# Altium schematic sheet Controller.SchDoc(Controller)
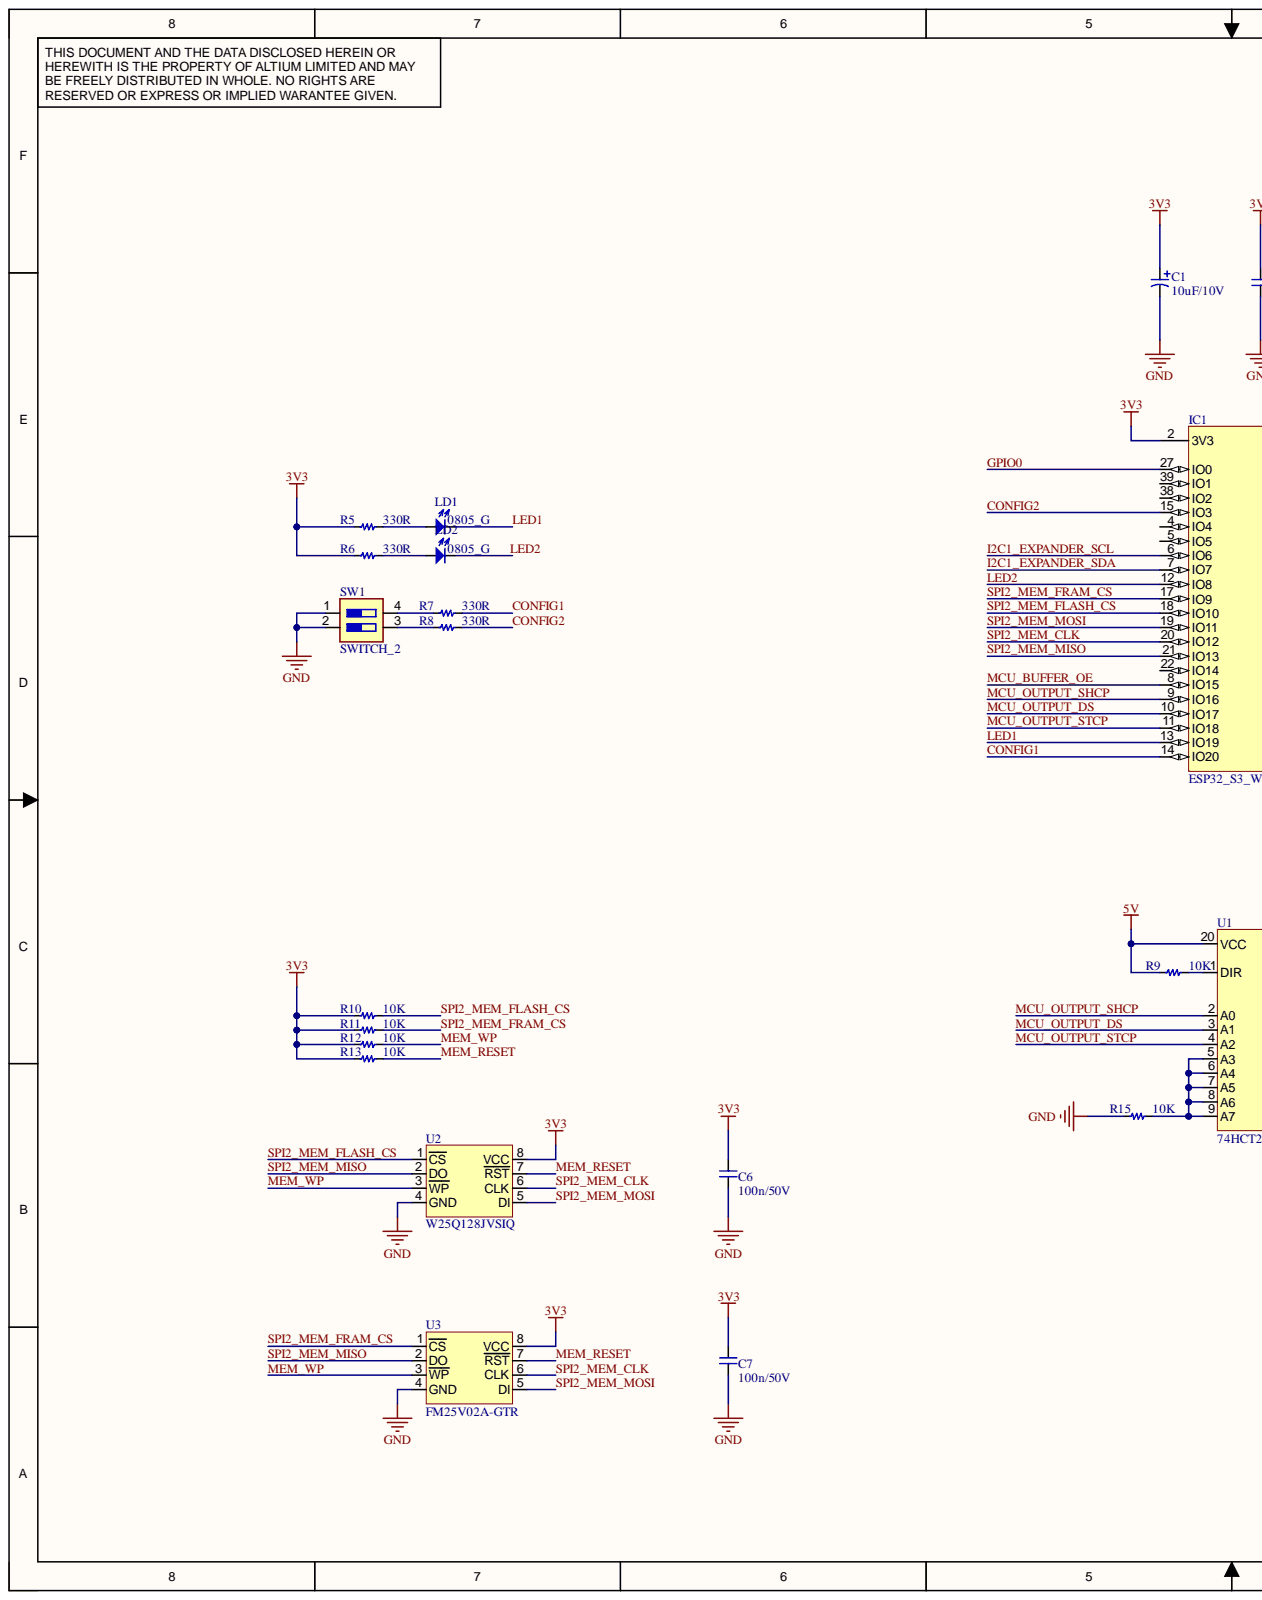
[diagram p0: Controller.SchDoc, left]
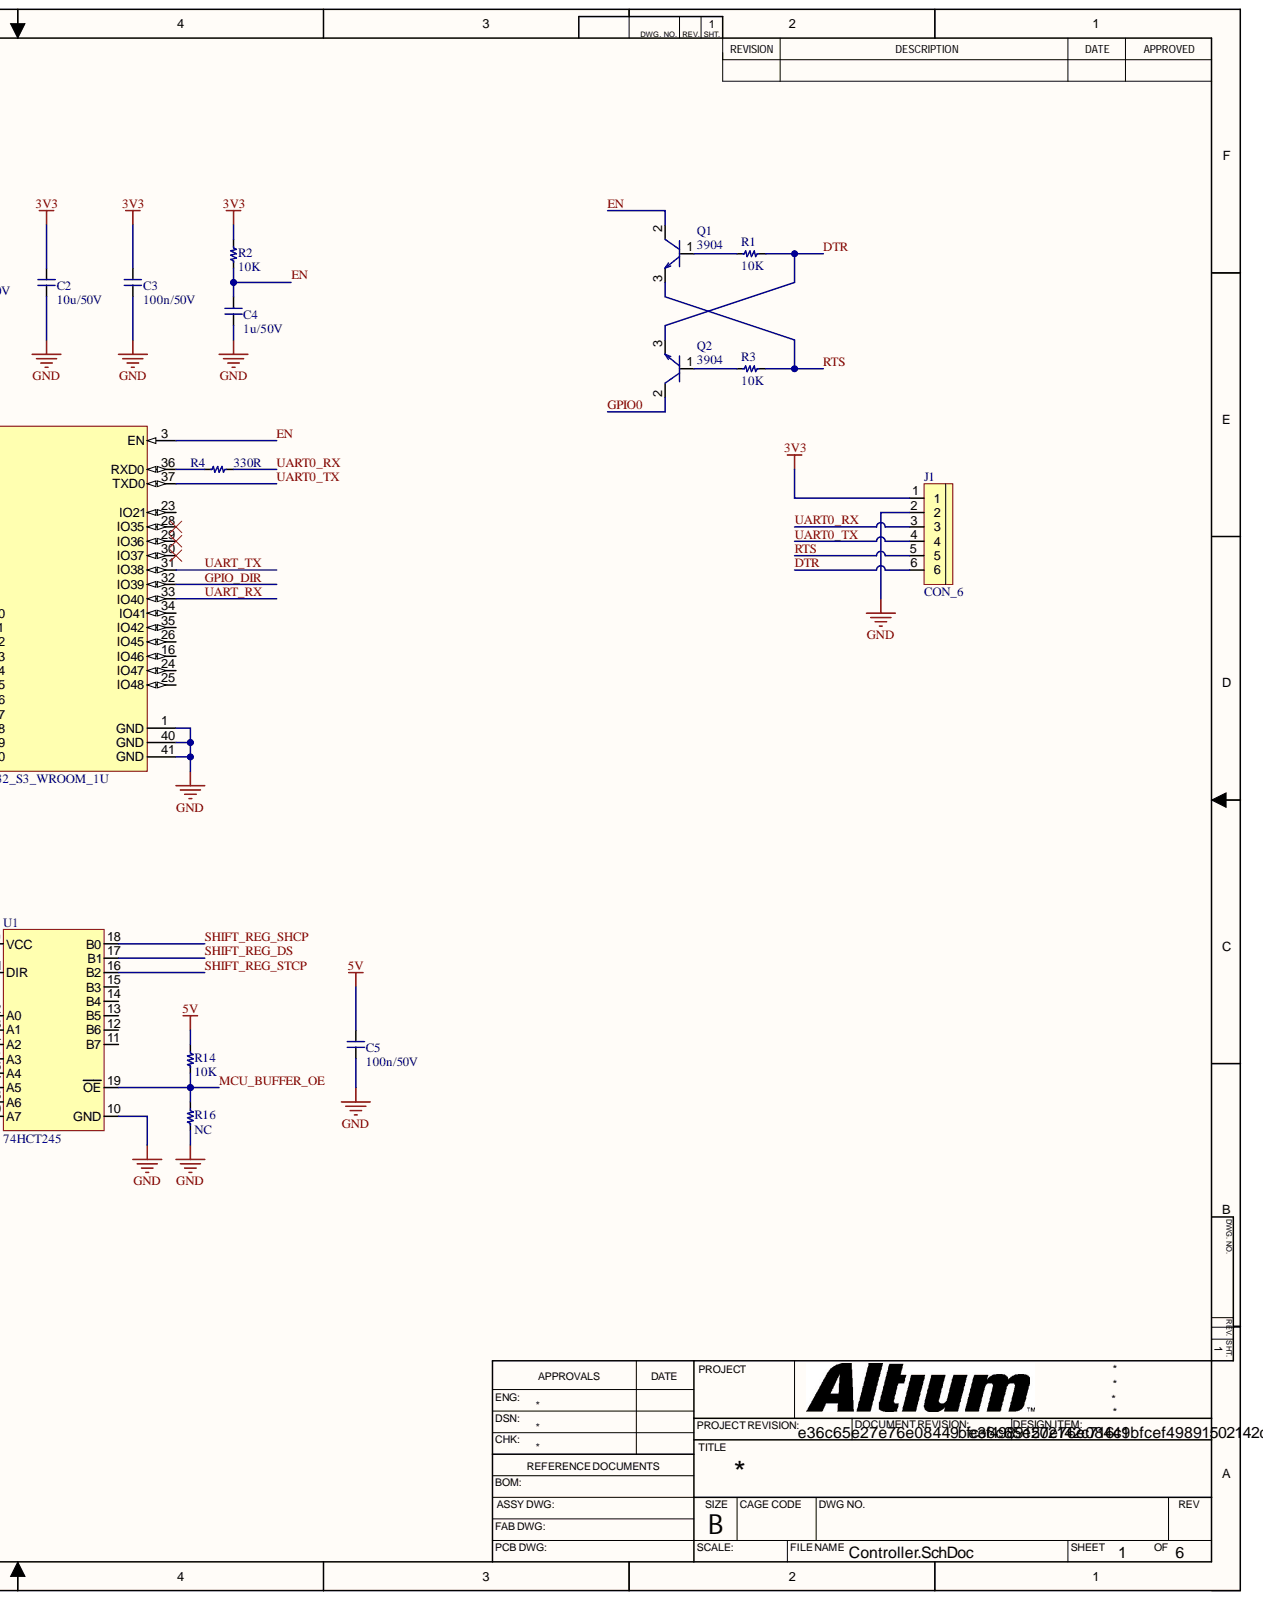
[diagram p1: Controller.SchDoc, right]

## Parts
C1  10uF/10V  [CAP_TANTA_CASE_A]
C2  10u/50V  [C-0805]
C3  100n/50V  [C-0805]
C4  1u/50V  [C-0805]
C5  100n/50V  [C-0805]
C6  100n/50V  [C-0805]
C7  100n/50V  [C-0805]
IC1  ESP32_S3_WROOM_1U  [ESP32_S3_WROOM_1U]
J1  CON_6  [MALE_HEADER_6]
LD1  0805_G  [LED_0805_G]
LD2  0805_G  [LED_0805_G]
Q1  3904  [SOT-23]
Q2  3904  [SOT-23]
R1  10K  [R-0805]
R2  10K  [R-0805]
R3  10K  [R-0805]
R4  330R  [R-0805]
R5  330R  [R-0805]
R6  330R  [R-0805]
R7  330R  [R-0805]
R8  330R  [R-0805]
R9  10K  [R-0805]
R10  10K  [R-0805]
R11  10K  [R-0805]
R12  10K  [R-0805]
R13  10K  [R-0805]
R14  10K  [R-0805]
R15  10K  [R-0805]
R16  NC  [R-0805]
SW1  SWITCH_2  [DIP_SW_2]
U1  74HCT245  [SOIC-20]
U2  W25Q128JVSIQ  [W25Q128JV]
U3  FM25V02A-GTR  [SOIC-8]

## Nets
3V3: C1.1 C2.2 C3.2 C6.2 C7.2 IC1.2(3V3) J1.1 R2.1 R5.2 R6.2 R10.2 R11.2 R12.2 R13.2 U2.8(VCC) U3.8(VCC)
5V: C5.2 R9.2 R14.1 U1.20(VCC)
GND: C1.2 C2.1 C3.1 C4.1 C5.1 C6.1 C7.1 IC1.1(GND) IC1.40(GND) IC1.41(GND) J1.2 R15.2 R16.2 SW1.1 SW1.2 U1.10(GND) U2.4(GND) U3.4(GND)
CONFIG1: IC1.14(IO20) R7.1
CONFIG2: IC1.15(IO3) R8.1
DTR: J1.6 Q2.3 R1.1
EN: C4.2 IC1.3(EN) Q1.2 R2.2
GPIO0: IC1.27(IO0) Q2.2
GPIO_DIR: IC1.32(IO39)
I2C1_EXPANDER_SCL: IC1.6(IO6)
I2C1_EXPANDER_SDA: IC1.7(IO7)
LED1: IC1.13(IO19) LD1.2
LED2: IC1.12(IO8) LD2.2
MCU_BUFFER_OE: IC1.8(IO15) R14.2 R16.1 U1.19(OE)
MCU_OUTPUT_DS: IC1.10(IO17) U1.3(A1)
MCU_OUTPUT_SHCP: IC1.9(IO16) U1.2(A0)
MCU_OUTPUT_STCP: IC1.11(IO18) U1.4(A2)
MEM_RESET: R13.1 U2.7(RST) U3.7(RST)
MEM_WP: R12.1 U2.3(WP) U3.3(WP)
RTS: J1.5 Q1.3 R3.1
SHIFT_REG_DS: U1.17(B1)
SHIFT_REG_SHCP: U1.18(B0)
SHIFT_REG_STCP: U1.16(B2)
SPI2_MEM_CLK: IC1.20(IO12) U2.6(CLK) U3.6(CLK)
SPI2_MEM_FLASH_CS: IC1.18(IO10) R10.1 U2.1(CS)
SPI2_MEM_FRAM_CS: IC1.17(IO9) R11.1 U3.1(CS)
SPI2_MEM_MISO: IC1.21(IO13) U2.2(DO) U3.2(DO)
SPI2_MEM_MOSI: IC1.19(IO11) U2.5(DI) U3.5(DI)
UART0_RX: J1.3 R4.1
UART0_TX: IC1.37(TXD0) J1.4
UART_RX: IC1.33(IO40)
UART_TX: IC1.31(IO38)
NetIC1_36: IC1.36(RXD0) R4.2
NetLD1_1: LD1.1 R5.1
NetLD2_1: LD2.1 R6.1
NetQ1_1: Q1.1 R1.2
NetQ2_1: Q2.1 R3.2
NetR7_2: R7.2 SW1.4
NetR8_2: R8.2 SW1.3
NetR9_1: R9.1 U1.1(DIR)
NetR15_1: R15.1 U1.5(A3) U1.6(A4) U1.7(A5) U1.8(A6) U1.9(A7)

Not connected: IC1 4(IO4) 5(IO5) 16(IO46) 22(IO14) 23(IO21) 24(IO47) 25(IO48) 26(IO45) 28(IO35) 29(IO36) 30(IO37) 34(IO41) 35(IO42) 38(IO2) 39(IO1); U1 11(B7) 12(B6) 13(B5) 14(B4) 15(B3)

## Library data of the parts
C1 = TANTALUM CAPACITOR: Component Kind: Standard; Library Name: NXVu.SchLib
C2-C7 = CERAMIC_CAP: Component Kind: Standard; Library Name: NXVu.SchLib
LD1 LD2 = LED_0805_G: Component Kind: Standard; Library Name: NXVu.SchLib
Q1 Q2 = TRANSISTOR_MMBT3904: Component Kind: Standard; Library Name: NXVu.SchLib
R1-R16 = RESISTOR: Component Kind: Standard; Library Name: NXVu.SchLib
U1 = 74HC245: Component Kind: Standard; Library Name: NXVu.SchLib
U2 U3 = W25Q128JV: Component Kind: Standard; Library Name: NXVu.SchLib
IC1 = ESP32_S3_WROOM_1U: Component Kind: Standard; Library Name: NXVu.SchLib
J1 = CON_6: Component Kind: Standard; Library Name: NXVu.SchLib
SW1 = SWITCH_2: Component Kind: Standard; Library Name: NXVu.SchLib
